# Altium schematic sheet FPGA_POWER_AND_CONFIGURATION.SchDoc
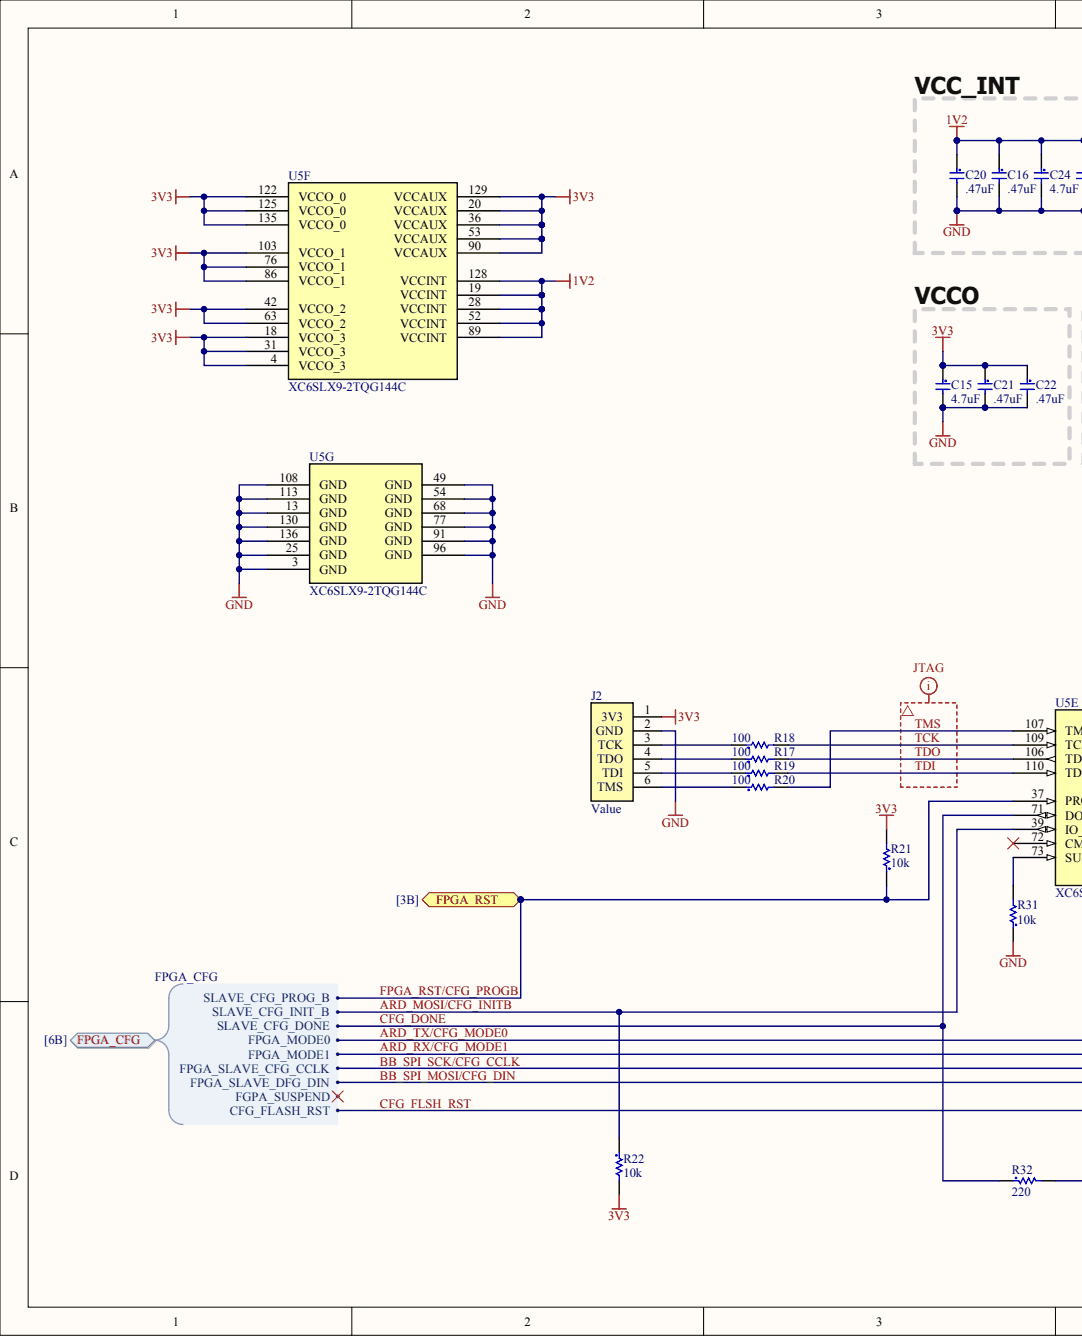
[diagram p0: FPGA_POWER_AND_CONFIGURATION.SchDoc, left]
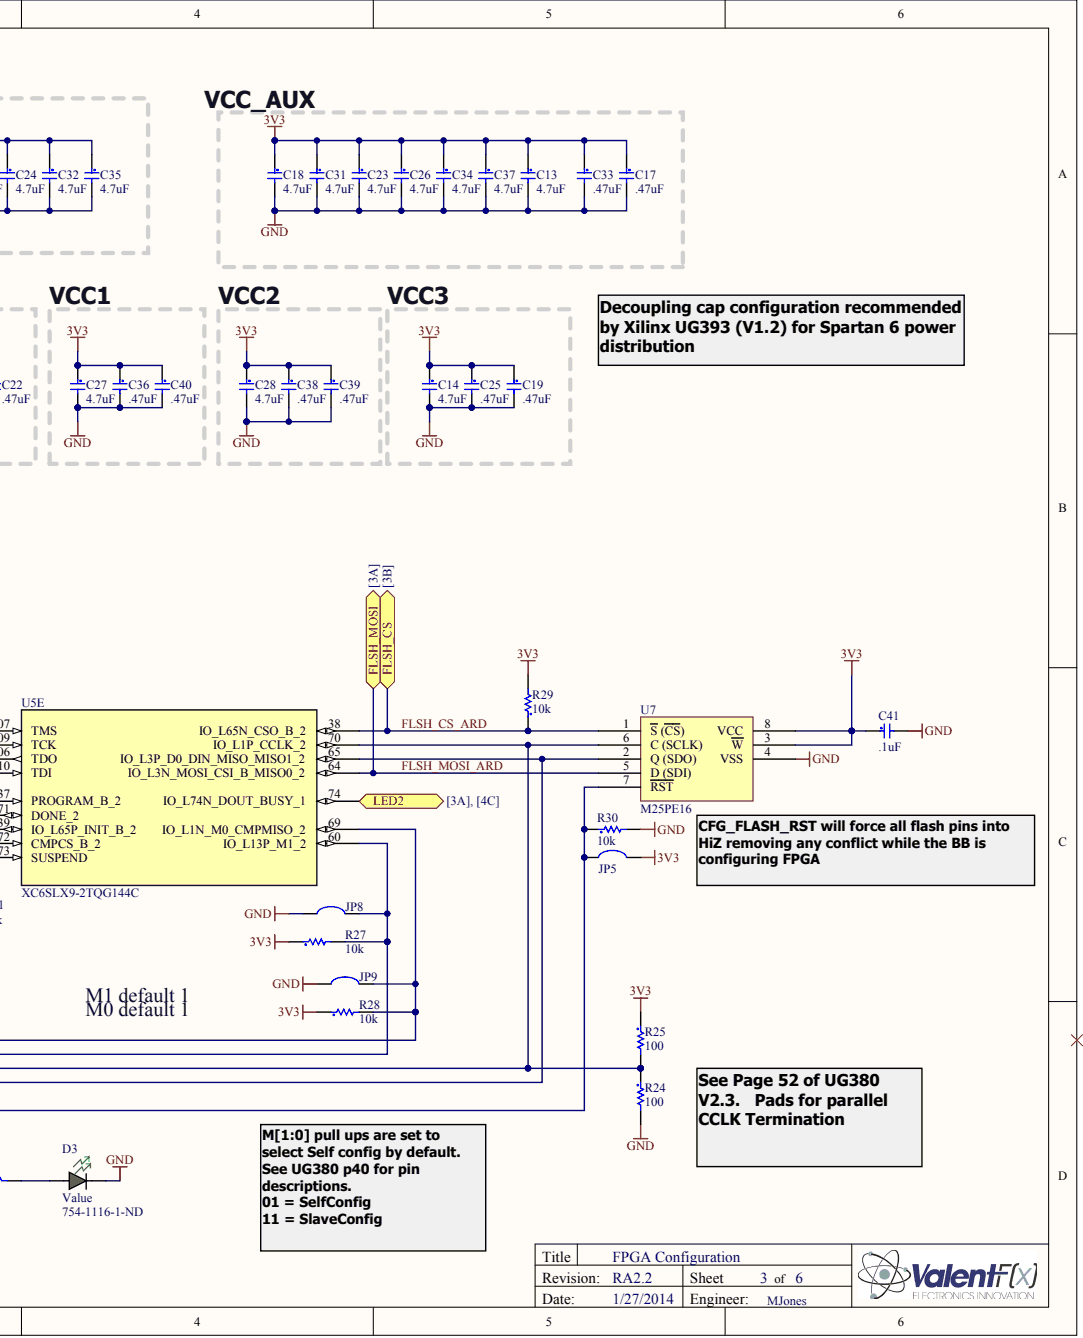
[diagram p1: FPGA_POWER_AND_CONFIGURATION.SchDoc, right]

## Parts
C13  4.7uF
C14  4.7uF
C15  4.7uF
C16  .47uF
C17  .47uF
C18  4.7uF
C19  .47uF
C20  .47uF
C21  .47uF
C22  .47uF
C23  4.7uF
C24  4.7uF
C25  .47uF
C26  4.7uF
C27  4.7uF
C28  4.7uF
C31  4.7uF
C32  4.7uF
C33  .47uF
C34  4.7uF
C35  4.7uF
C36  .47uF
C37  4.7uF
C38  .47uF
C39  .47uF
C40  .47uF
C41  .1uF
D3  Supplier Part Number 1: 754-1116-1-ND
J2  =VALUE
JP5  Solder Jumper  (value not drawn)
JP8  Solder Jumper  (value not drawn)
JP9  Solder Jumper  (value not drawn)
R17  100
R18  100
R19  100
R20  100
R21  10k
R22  10k
R24  100
R25  100
R27  10k
R28  10k
R29  10k
R30  10k
R31  10k
R32  220
U5  XC6SLX9-2TQG144C  (parts E,F,G)
U7  M25PE16

## Ports
FLSH_CS, FLSH_MOSI, FPGA_CFG, FPGA_CFG.CFG_FLASH_RST, FPGA_CFG.FGPA_SUSPEND, FPGA_CFG.FPGA_MODE0, FPGA_CFG.FPGA_MODE1, FPGA_CFG.FPGA_SLAVE_CFG_CCLK, FPGA_CFG.FPGA_SLAVE_DFG_DIN, FPGA_CFG.SLAVE_CFG_DONE, FPGA_CFG.SLAVE_CFG_INIT_B, FPGA_CFG.SLAVE_CFG_PROG_B, FPGA_RST, LED2

## Nets
1V2: C16.1 C20.1 C24.1 C32.1 C35.1 U5.19(VCCINT) U5.28(VCCINT) U5.52(VCCINT) U5.89(VCCINT) U5.128(VCCINT)
3V3: C13.1 C14.1 C15.1 C17.1 C18.1 C19.1 C21.1 C22.1 C23.1 C25.1 C26.1 C27.1 C28.1 C31.1 C33.1 C34.1 C36.1 C37.1 C38.1 C39.1 C40.1 C41.1 J2.1(3V3) JP5.1 R21.2 R22.2 R25.1 R27.1 R28.1 R29.2 U5.4(VCCO_3) U5.18(VCCO_3) U5.20(VCCAUX) U5.31(VCCO_3) U5.36(VCCAUX) U5.42(VCCO_2) U5.53(VCCAUX) U5.63(VCCO_2) U5.76(VCCO_1) U5.86(VCCO_1) U5.90(VCCAUX) U5.103(VCCO_1) U5.122(VCCO_0) U5.125(VCCO_0) U5.129(VCCAUX) U5.135(VCCO_0) U7.3(W) U7.8(VCC)
GND: C13.2 C14.2 C15.2 C16.2 C17.2 C18.2 C19.2 C20.2 C21.2 C22.2 C23.2 C24.2 C25.2 C26.2 C27.2 C28.2 C31.2 C32.2 C33.2 C34.2 C35.2 C36.2 C37.2 C38.2 C39.2 C40.2 C41.2 D3.C J2.2(GND) JP8.2 JP9.2 R24.2 R30.2 R31.1 U5.3(GND) U5.13(GND) U5.25(GND) U5.49(GND) U5.54(GND) U5.68(GND) U5.77(GND) U5.91(GND) U5.96(GND) U5.108(GND) U5.113(GND) U5.130(GND) U5.136(GND) U7.4(VSS)
ARD_MOSI/CFG_INITB: R22.1 U5.39(IO_L65P_INIT_B_2) ; port FPGA_CFG
ARD_RX/CFG_MODE1: JP8.1 R27.2 U5.60(IO_L13P_M1_2) ; port FPGA_CFG
ARD_TX/CFG_MODE0: JP9.1 R28.2 U5.69(IO_L1N_M0_CMPMISO_2) ; port FPGA_CFG
BB_SPI_MOSI/CFG_DIN: U5.65(IO_L3P_D0_DIN_MISO_MISO1_2) U7.2(Q_(SDO)) ; port FPGA_CFG
BB_SPI_SCK/CFG_CCLK: R24.1 R25.2 U5.70(IO_L1P_CCLK_2) U7.6(C_(SCLK)) ; port FPGA_CFG
CFG_DONE: R32.1 U5.71(DONE_2) ; port FPGA_CFG
CFG_FLSH_RST: JP5.2 R30.1 U7.7(RST) ; port FPGA_CFG
FLSH_CS_ARD: R29.1 U5.38(IO_L65N_CSO_B_2) U7.1(S_(CS)) ; port FLSH_CS
FLSH_MOSI_ARD: U5.64(IO_L3N_MOSI_CSI_B_MISO0_2) U7.5(D_(SDI)) ; port FLSH_MOSI
FPGA_RST/CFG_PROGB: R21.1 U5.37(PROGRAM_B_2) ; port FPGA_CFG, port FPGA_RST
LED2: U5.74(IO_L74N_DOUT_BUSY_1) ; port LED2
TCK: R18.2 U5.109(TCK)
TDI: R19.2 U5.110(TDI)
TDO: R17.2 U5.106(TDO)
TMS: R20.2 U5.107(TMS)
NetD3_A: D3.A R32.2
NetJ2_3: J2.3(TCK) R18.1
NetJ2_4: J2.4(TDO) R17.1
NetJ2_5: J2.5(TDI) R19.1
NetJ2_6: J2.6(TMS) R20.1
NetR31_2: R31.2 U5.73(SUSPEND)
N00122:
N00123: ; port FPGA_CFG

Not connected: U5 72(CMPCS_B_2)

## Library data of the parts
C13-C15 C18 C23 C24 C26-C28 C31 C32 C34 C35 C37 = (no symbol name): Manufacturer: Murata Electronics North America; Manufacturer Part Number: GRM188R60J475KE19D; Capacitance: 4.7µF; Tolerance: ±10%; Voltage - Rated: 6.3V; Temperature Coefficient: X5R; Supplier 1: Digi-Key; RoHS: RoHS Compliant; Category: Capacitors; Stock 1: 614848; Pricing 1: 1=0.19, 10=0.132, 50=0.076, 100=0.0627, 250=0.05284, 500=0.04426, 1000=0.03633 (USD); Package / Case: 0603 (1608 Metric); Packaging: Cut Tape (CT); ComponentLink1URL: http://search.murata.co.jp/Ceramy/image/img/PDF/ENG/GRM188R60J475KE19.pdf; ComponentLink1Description: http://search.murata.co.jp/Ceramy/image/img/PDF/ENG/GRM188R60J475KE19.pdf; Value: 4.7uF; Mounting Type: Surface Mount, MLCC; Operating Temperature: -55°C ~ 85°C; Applications: General Purpose; Ratings: -; Size / Dimension: 0.063" L x 0.031" W (1.60mm x 0.80mm); Height - Seated (Max): -; Thickness (Max): 0.035" (0.90mm); Lead Spacing: -; Features: -; Lead Style: -; Supplier Part Number 1: 490-3297-1-ND
C16 C17 C19-C22 C25 C33 C36 C38-C40 = (no symbol name): Manufacturer: Murata Electronics North America; Manufacturer Part Number: GCM188R71E474KA64D; Capacitance: 0.47µF; Voltage - Rated: 25V; Tolerance: ±10%; Temperature Coefficient: X7R; Supplier 1: Digi-Key; Supplier Part Number 1: 490-4776-1-ND; RoHS: RoHS Compliant; Stock 1: 100000; Pricing 1: 1=0.26, 10=0.214, 50=0.1202, 100=0.1068, 250=0.08012, 500=0.07076, 1000=0.06275 (USD); ComponentLink1URL: http://search.murata.co.jp/Ceramy/image/img/PDF/ENG/GCM188R71E474KA64.pdf; ComponentLink1Description: http://search.murata.co.jp/Ceramy/image/img/PDF/ENG/GCM188R71E474KA64.pdf; Value: .47uF; Category: Capacitors; Mounting Type: Surface Mount, MLCC; Operating Temperature: -55°C ~ 125°C; Applications: Automotive; Ratings: AEC-Q200; Package / Case: 0603 (1608 Metric); Size / Dimension: 0.063" L x 0.031" W (1.60mm x 0.80mm); Height - Seated (Max): -; Thickness (Max): 0.035" (0.90mm); Lead Spacing: -; Features: -; Packaging: Cut Tape (CT); Lead Style: -
C41 = (no symbol name): Manufacturer: Murata; Manufacturer Part Number: GRM155R61C104KA88D; Capacitance: 0.1 uF; Tolerance: 10 %; Voltage Rating: 16 Volts; Temperature Coefficient / Code: X5R; Value: .1uF; Supplier 1: Mouser; Supplier Part Number 1: 81-GRM155R61C104KA8D; RoHS: RoHS Compliant By Exemption; Category: Multilayer Ceramic Capacitors (MLCC) - SMD/SMT; Stock 1: 478408; Pricing 1: 1=0.122, 50=0.034, 100=0.026, 500=0.014, 1000=0.009, 10000=0.005, 20000=0.004 (AUD); Package / Case: 0402 (1005 metric); Packaging: Reel; ComponentLink1URL: http://www.murata.com/products/catalog/pdf/c02e.pdf; ComponentLink1Description: http://www.murata.com/products/catalog/pdf/c02e.pdf; Dimensions: 0.5 mm W x 1 mm L x 0.5 mm H; Operating Temperature Range: - 55 C to + 85 C; Product: General Type MLCCs; Series: GRM; Termination Style: SMD/SMT; Product Category: Low & Medium Capacitance SMD Ceramic Chip Capacitors; Standard Pack Qty: 10000; RoHS - Mouser: E; Minimum Order Quantity: 1; Reeling: True
D3 = (no symbol name): Manufacturer: Kingbright Corp; Manufacturer Part Number: APT1608CGCK; Color: Green; Current - Test: 20mA; Wavelength - Dominant: 570nm; Wavelength - Peak: 574nm; Millicandela Rating: 50mcd; Voltage - Forward (Vf) Typ: 2.1V; Lens Type: Clear; Lens Style/Size: Rectangle with Flat Top, 1.2mm x 0.8mm; Viewing Angle: 120°; Supplier 1: Digi-Key; RoHS: RoHS Compliant; Category: Optoelectronics; Stock 1: 98122; Pricing 1: 1=0.2, 10=0.153, 25=0.1224, 100=0.1105, 250=0.102, 500=0.0918 (USD); Luminous Flux @ Current - Test: -; Size / Dimension: 1.60mm L x 0.80mm W; Height: 0.75mm; Package / Case: 0603 (1608 Metric); Mounting Type: Surface Mount; Packaging: Cut Tape (CT); ComponentLink1URL: http://www.us.kingbright.com/images/catalog/SPEC/APT1608CGCK.pdf; ComponentLink1Description: http://www.us.kingbright.com/images/catalog/SPEC/APT1608CGCK.pdf; Comment: =Value
J2 = (no symbol name): Manufacturer: Samtec; Manufacturer Part Number: TSW-106-08-G-S-RA; Lead Time: 0 weeks; Peak Solder Temperature: 260 deg C; MSL Level Min: N/R; Connector Type: Header, Unshrouded; Number of Positions: 6; Number of Positions Loaded: All; Pitch: 0.100" (2.54mm); Supplier 1: Arrow; RoHS: CHINA ROHS: Compliant / EU ROHS: Compliant; Stock 1: 0; Pricing 1: 0=0.2649 (USD); ComponentLink1URL: http://components.arrow.com/partservice/dataview/altium/2677508S3639200N1004?apiKey=aa438727d59eed98c4bed826d8d949c84cb5e685b92bf87346a0226bd2658797; ComponentLink1Description: http://components.arrow.com/partservice/dataview/altium/2677508S3639200N1004?apiKey=aa438727d59eed98c4bed826d8d949c84cb5e685b92bf87346a0226bd2658797; Supplier Part Number 1: 2677508S3639200N1004; Package Quantity: 1; Termination Finish: Au/Ni; MSL Level Max: N/R; MSL (Moisture Sensitivity Level): N/R; China ROHS Lead (X -> above / O -> below threshold): O; China ROHS Mercury (X -> above / O -> below threshold): O; China ROHS Cadmium (X -> above / O -> below threshold): O; China ROHS Hex Chromium (X -> above / O -> below threshold): O; China ROHS PBB (X -> above / O -> below threshold): O; China ROHS PBDE (X -> above / O -> below threshold): O; EU_ROHS_PROD_EXEMPTION_BY_SUPP: N/R; Supplier 2: Digi-Key; Category: Connectors, Interconnects; Stock 2: 0; Pricing 2: 1=0.94, 10=0.841, 100=0.6926, 500=0.5475, 1000=0.46999, 5000=0.42877, 10000=0.41228 (USD); Contact Type: Male Pin; Number of Rows: 1; Row Spacing: -; Contact Mating Length: 0.230" (5.84mm); Mounting Type: Through Hole; Termination: Solder; Fastening Type: -; Features: -; Contact Finish: Gold; Contact Finish Thickness: 10µin (0.25µm); Color: Black; Packaging: Bulk; ComponentLink2URL: http://www.samtec.com/documents/webfiles/cpdf/TSW-XXX-XX-XXX-X-XX-XXX-MKT.pdf; ComponentLink2Description: http://www.samtec.com/documents/webfiles/cpdf/TSW-XXX-XX-XXX-X-XX-XXX-MKT.pdf; Supplier Part Number 2: SAM1038-06-ND
R17-R20 R24 R25 = (no symbol name): Manufacturer: Vishay/Dale; Manufacturer Part Number: CRCW0603100RFKEA; Power Rating: 0.1 Watt (1/10 Watt); Resistance: 100 Ohms; Temperature Coefficient: 100 PPM / K; Tolerance: 1 %; Voltage Rating: 75 Volts; Supplier 1: Mouser; RoHS: RoHS Compliant By Exemption; Category: Thick Film Resistors - SMD; Stock 1: 243353; Pricing 1: 1=0.108, 25=0.054, 100=0.034, 1000=0.020, 2000=0.018, 5000=0.008, 10000=0.007, 50000=0.005 (AUD); Dimensions: 0.85 mm W x 1.55 mm L x 0.45 mm H; Operating Temperature Range: - 55 C to + 155 C; Package / Case: 0603 (1608 metric); Packaging: Reel; Product: Thick Film Resistors SMD; Series: CRCW; Termination Style: SMD/SMT; Product Category: 0603 Thick Film Chip Resistors; Standard Pack Qty: 5000; RoHS - Mouser: E; Minimum Order Quantity: 1; Reeling: True; ComponentLink1URL: http://www.vishay.com/doc?20035; ComponentLink1Description: http://www.vishay.com/doc?20035; Supplier Part Number 1: 71-CRCW0603-100-E3; Value: 100
R21 R22 R27-R31 = (no symbol name): Manufacturer: Vishay Dale; Manufacturer Part Number: CRCW060310K0FKEA; Power (Watts): 0.1W, 1/10W; Temperature Coefficient: ±100ppm/°C; Tolerance: ±1%; Value: 10k; Supplier 1: Digi-Key; RoHS: RoHS Compliant; Category: Resistors; Stock 1: 997856; Pricing 1: 10=0.081, 50=0.0436, 200=0.0251, 1000=0.01711 (USD); Resistance (Ohms): 10K; Composition: Thick Film; Features: -; Size / Dimension: 0.061" L x 0.033" W (1.55mm x 0.85mm); Height: 0.020" (0.50mm); Packaging: Cut Tape (CT); Package / Case: 0603 (1608 Metric); ComponentLink1URL: http://www.vishay.com/doc?20035; ComponentLink1Description: http://www.vishay.com/doc?20035; Supplier Part Number 1: 541-10.0KHCT-ND; Number of Terminations: 2
R32 = (no symbol name): Manufacturer: Panasonic - ECG; Manufacturer Part Number: ERJ-3EKF2200V; Power (Watts): 0.1W, 1/10W; Temperature Coefficient: ±100ppm/°C; Tolerance: ±1%; Value: 220; Supplier 1: Digi-Key; Supplier Part Number 1: P220HCT-ND; RoHS: RoHS Compliant; Category: Resistors; Stock 1: 517798; Pricing 1: 1=0.1, 50=0.0138, 100=0.0105, 250=0.0078, 500=0.00576, 1000=0.00384 (USD); Resistance (Ohms): 220; Composition: Thick Film; Features: -; Size / Dimension: 0.063" L x 0.031" W (1.60mm x 0.80mm); Height: 0.022" (0.55mm); Packaging: Cut Tape (CT); Package / Case: 0603 (1608 Metric); ComponentLink1URL: http://industrial.panasonic.com/www-cgi/jvcr13pz.cgi?E+PZ+3+AOA0002+ERJ3EKF2200V+7+WW; ComponentLink1Description: http://industrial.panasonic.com/www-cgi/jvcr13pz.cgi?E+PZ+3+AOA0002+ERJ3EKF2200V+7+WW; Number of Terminations: 2
U5 = (no symbol name): Manufacturer: Xilinx Inc; Number of Logic Elements/Cells: 9152; Number of LABs/CLBs: 715; Total RAM Bits: 589824; Number of I /O: 102; Voltage - Supply: 1.14 V ~ 1.26 V; Supplier 1: America II Electronics; Supplier Part Number 1: 122-1745-ND; Manufacturer Part Number: XC6SLX9-2TQG144C; RoHS: RoHS Compliant; Category: Integrated Circuits (ICs); Stock 1: 994; Pricing 1: 1=12.80,1=11.05,1000=9.59 (USD); Number of Gates: -; Mounting Type: Surface Mount; Operating Temperature: 0°C ~ 85°C; Package / Case: 144-LQFP; Supplier Device Package: 144-TQFP (20x20); ComponentLink1URL: http://www.xilinx.com/support/documentation/data_sheets/ds160.pdf; ComponentLink1Description: http://www.xilinx.com/support/documentation/data_sheets/ds160.pdf; Value: XC6SLX9-2TQG144C; Supplier 2: Digi-Key
U7 = (no symbol name): Manufacturer: Numonyx/ST Micro; Manufacturer Part Number: M25PE16-VMW6TG; Format - Memory: FLASH; Memory Size: 16M (2M x 8); Speed: 75MHz; Interface: SPI, 3-Wire Serial; Voltage - Supply: 2.7 V ~ 3.6 V; Supplier 1: Digi-Key; RoHS: RoHS Compliant; Category: Integrated Circuits (ICs); Stock 1: 866; Pricing 1: 1=2.31, 10=1.98, 25=1.782, 100=1.617, 250=1.452, 500=1.254 (USD); Memory Type: FLASH; Operating Temperature: -40°C ~ 85°C; Package / Case: 8-SOIC (0.200", 5.30mm Width); Packaging: Cut Tape (CT); Supplier Device Package: *; ComponentLink1URL: http://www.numonyx.com/Documents/Datasheets/M25PE16.pdf; ComponentLink1Description: http://www.numonyx.com/Documents/Datasheets/M25PE16.pdf; Supplier Part Number 1: M25PE16-VMW6TGCT-ND; Value: M25PE16
JP5 JP8 JP9 = (no symbol name): Manufacturer: n/a; Manufacturer Part Number: n/a; Source: n/a; Source Part Number: n/a; ROHS Certificate: n/a
